# Altium schematic sheet isolation_verifier.SchDoc
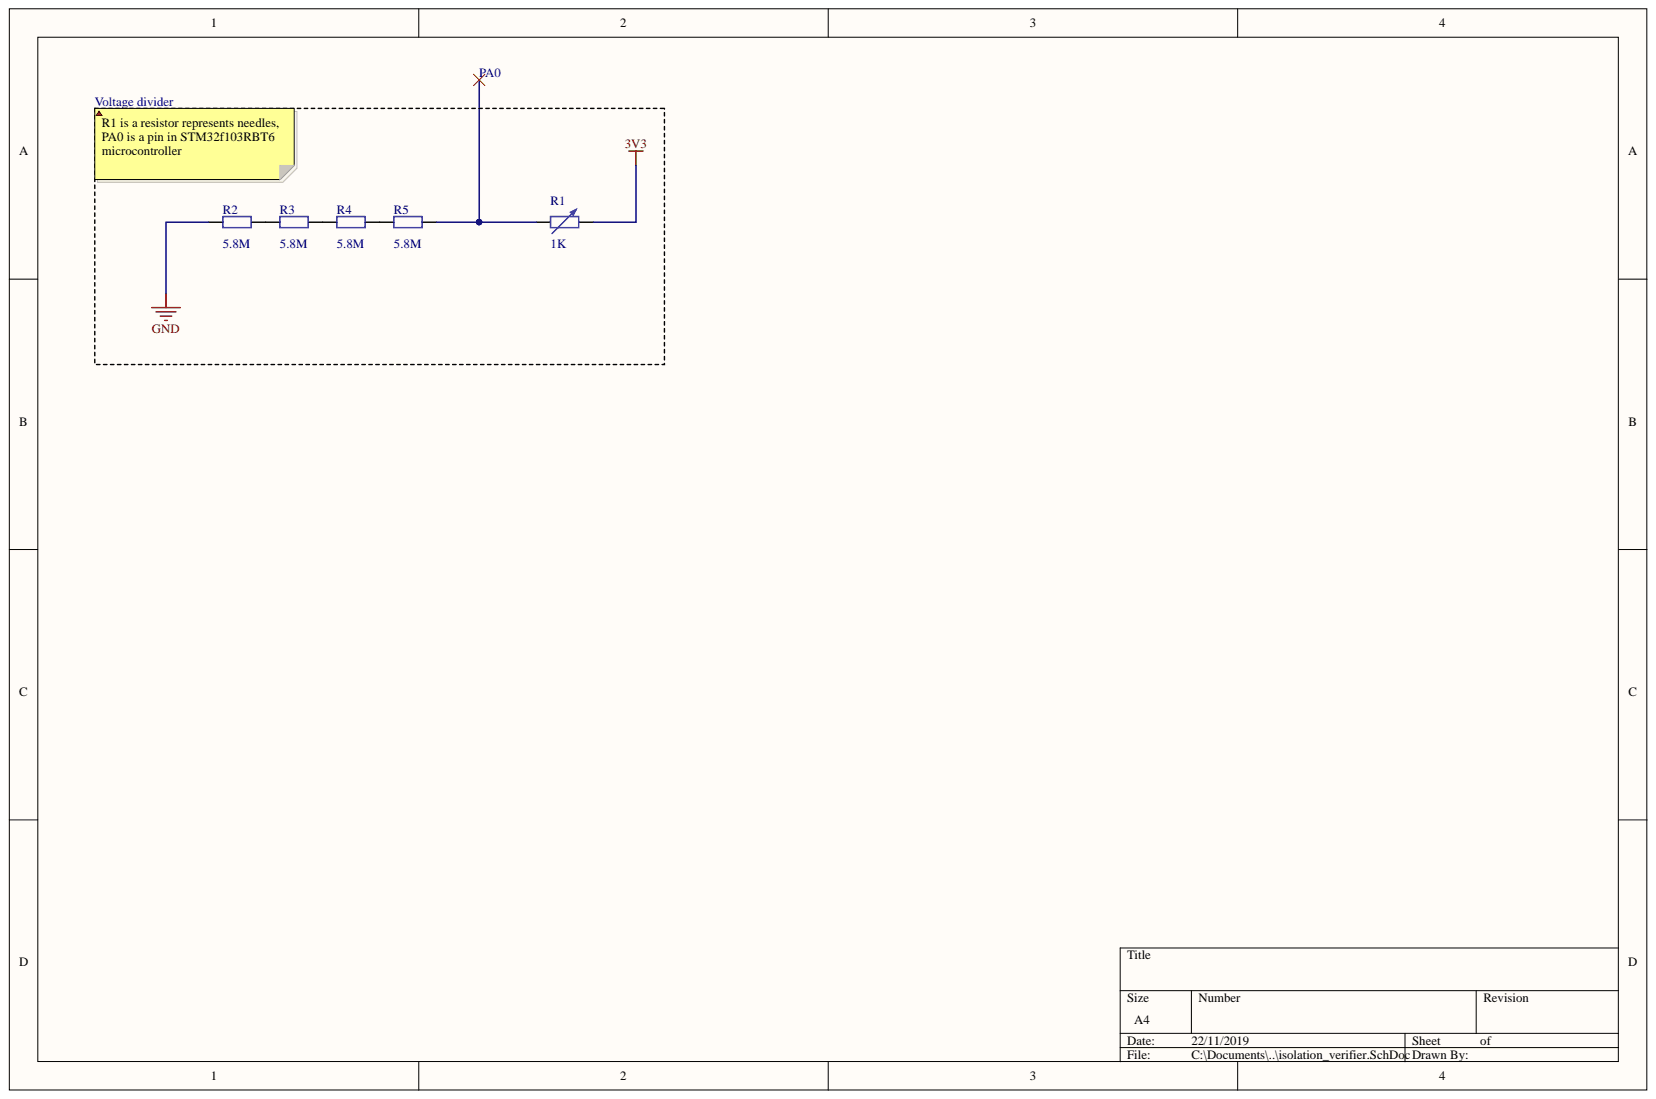
[diagram p0: isolation_verifier.SchDoc]

## Parts
R1  value 1K  [AXIAL-0.6]  (hidden comment: Res Adj2)
R2  value 5.8M  [AXIAL-0.4]  (hidden comment: Res2)
R3  value 5.8M  [AXIAL-0.4]  (hidden comment: Res2)
R4  value 5.8M  [AXIAL-0.4]  (hidden comment: Res2)
R5  value 5.8M  [AXIAL-0.4]  (hidden comment: Res2)

## Nets
3V3: R1.2
GND: R2.1
NetR1_1: R1.1 R5.2
NetR2_2: R2.2 R3.1
NetR3_2: R3.2 R4.1
NetR4_2: R4.2 R5.1

## Library data of the parts
R1 = Res Adj2: Variable Resistor; Set Position: 0.5; Component Kind: Standard; LatestRevisionDate: 17-Jul-2002; LatestRevisionNote: Re-released for DXP Platform.; Library Name: Miscellaneous Devices.IntLib; PackageDescription: Axial Device, Thru-Hole; 2 Leads; 0.6 in Pin Spacing; PackageReference: AXIAL-0.6; Published: 8-Jun-2000; Signal Integrity: Res Adj; Simulation: VRES; Publisher: Altium Limited
R2-R5 = Res2: Resistor; Component Kind: Standard; LatestRevisionDate: 17-Jul-2002; LatestRevisionNote: Re-released for DXP Platform.; Library Name: Miscellaneous Devices.IntLib; PackageDescription: Axial Device, Thru-Hole; 2 Leads; 0.4 in Pin Spacing; PackageReference: AXIAL-0.4; Published: 8-Jun-2000; Signal Integrity: Res; Simulation: RESISTOR; Publisher: Altium Limited
